# Altium schematic sheet CAM.SchDoc
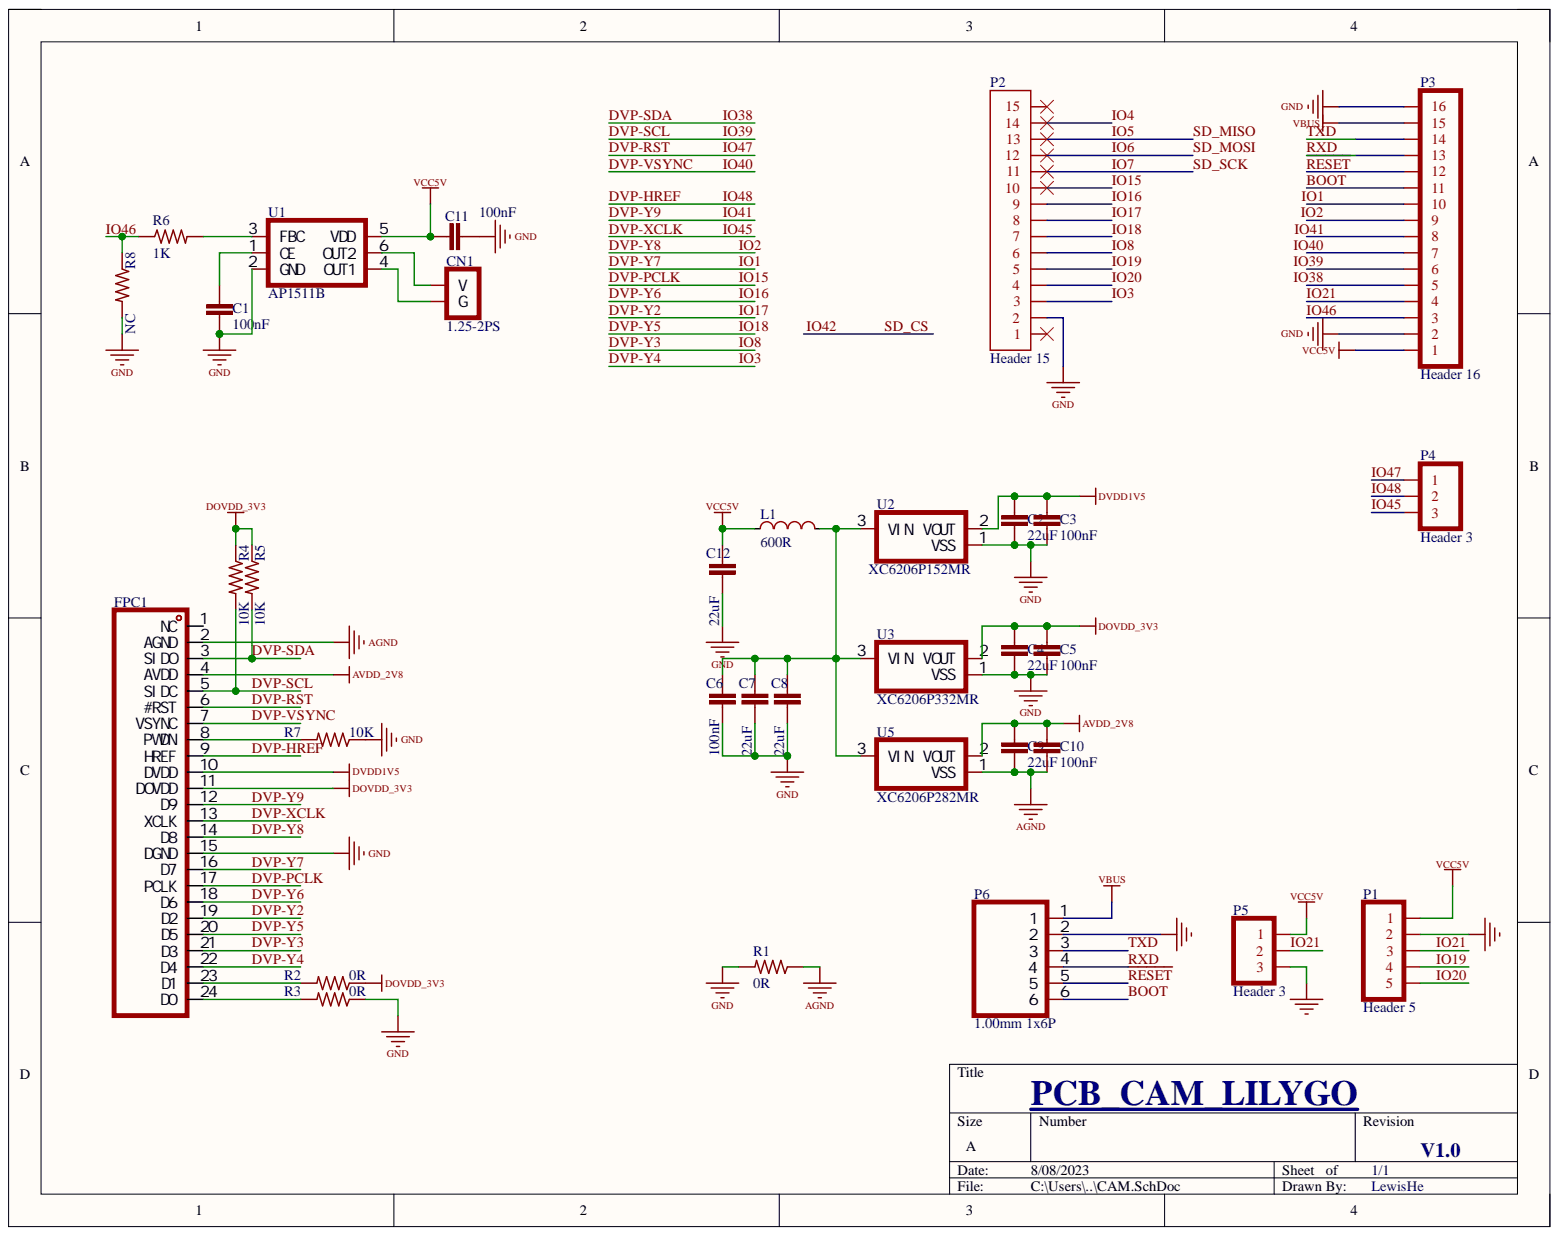
[diagram p0: CAM.SchDoc]

## Parts
C1  100nF  [C0402]
C2  22uF  [C0603]
C3  100nF  [C0603]
C4  22uF  [C0603]
C5  100nF  [C0603]
C6  100nF  [C0603]
C7  22uF  [C0603]
C8  22uF  [C0603]
C9  22uF  [C0603]
C10  100nF  [C0603]
C11  100nF  [C0603]
C12  22uF  [C0603]
CN1  1.25-2PS  [CONN-SMD_2P-P1.25_DEALON_1.25-2PWB]
FPC1  FPC-05FB-24PH20_1  [FPC-SMD_24P-P0.50_FPC-05FB-24PH20]  (value not drawn)
L1  600R  [L0805]
P1  Header 5  [HDR1X5]
P2  Header 15  [HDR1X15]
P3  Header 16  [HDR1X16]
P4  Header 3  [HDR1X3]
P5  Header 3  [HDR1X3-排母]
P6  1.00mm 1x6P  [CONN-SMD_HCTL_HC-1.0-6PWT]
R1  0R  [R0402]
R2  0R  [R0402]
R3  0R  [R0402]
R4  10K  [R0402]
R5  10K  [R0402]
R6  1K  [R0402]
R7  10K  [R0402]
R8  NC  [R0402]
U1  AP1511B  [SOT-26_L3.0-W1.6-P0.95-LS2.8-BL]
U2  XC6206P152MR  [SOT-23-3_L2.9-W1.6-P1.90-LS2.8-BR]
U3  XC6206P332MR  [SOT-23-3_L2.9-W1.6-P1.90-LS2.8-BR]
U5  XC6206P282MR  [SOT-23-3_L2.9-W1.6-P1.90-LS2.8-BR]

## Nets
AGND: C9.2 C10.2 FPC1.2(AGND) R1.1 U5.1
AVDD_2V8: C9.1 C10.1 FPC1.4(AVDD) U5.2
DOVDD_3V3: C4.1 C5.1 FPC1.11(DOVDD) R2.1 R4.1 R5.1 U3.2
DVDD1V5: C2.1 C3.1 FPC1.10(DVDD) U2.2
GND: C1.1 C2.2 C3.2 C4.2 C5.2 C6.2 C7.1 C8.1 C11.2 C12.1 CN1.3 CN1.4 FPC1.15(DGND) FPC1.25 FPC1.26 P1.2 P2.2 P3.2 P3.16 P5.3 P6.2 P6.7 P6.8 R1.2 R3.1 R7.1 R8.2 U1.2(GND) U2.1 U3.1
VBUS: P3.15 P6.1
VCC5V: C11.1 C12.2 L1.2 P1.1 P3.1 P5.1 U1.5(VDD)
BOOT: P3.11 P6.6
DVP-HREF: FPC1.9(HREF) P4.2
DVP-PCLK: FPC1.17(PCLK) P2.10
DVP-RST: FPC1.6(#RST) P4.1
DVP-SCL: FPC1.5(SIDC) P3.6 R4.2
DVP-SDA: FPC1.3(SIDO) P3.5 R5.2
DVP-VSYNC: FPC1.7(VSYNC) P3.7
DVP-XCLK: FPC1.13(XCLK) P4.3
DVP-Y2: FPC1.19(D2) P2.8
DVP-Y3: FPC1.21(D3) P2.6
DVP-Y4: FPC1.22(D4) P2.3
DVP-Y5: FPC1.20(D5) P2.7
DVP-Y6: FPC1.18(D6) P2.9
DVP-Y7: FPC1.16(D7) P3.10
DVP-Y8: FPC1.14(D8) P3.9
DVP-Y9: FPC1.12(D9) P3.8
IO4: P2.14
IO5: P2.13
IO6: P2.12
IO7: P2.11
IO19: P1.4 P2.5
IO20: P1.5 P2.4
IO21: P1.3 P3.4 P5.2
IO46: P3.3 R6.1 R8.1
~{RESET}: P3.12 P6.5
RXD: P3.13 P6.4
TXD: P3.14 P6.3
NetC1_2: C1.2 U1.1(CE)
NetC6_1: C6.1 C7.2 C8.2 L1.1 U2.3 U3.3 U5.3
NetCN1_1: CN1.1 U1.4(OUT1)
NetCN1_2: CN1.2 U1.6(OUT2)
NetFPC1_8: FPC1.8(PWDN) R7.2
NetFPC1_23: FPC1.23(D1) R2.2
NetFPC1_24: FPC1.24(D0) R3.2
NetR6_2: R6.2 U1.3(FBC)
IO42:

Not connected: FPC1 1(NC); P2 1 15

## Library data of the parts
C1-C12 = C0201: 0201  贴片电容; Component Kind: Standard; Library Name: 常用.SCHLIB
CN1 = 1.25-2PS: 1.25mm 1x2P 立贴 卧贴; 系统型号: 暂无; Component Kind: Standard; Library Name: 常用.SCHLIB; 立创链接: https://item.szlcsc.com/3172274.html
FPC1 = FPC-05FB-24PH20_1: OV2640 下接接口,https://item.szlcsc.com/3056929.html; Component Kind: Standard; Library Name: 常用.SCHLIB
L1 = L0603: Component Kind: Standard; Description: L0603; Library Name: 常用.SCHLIB
P1 = Header 5: 2.54mm 1x5 排针; Component Kind: Standard; Library Name: 常用.SCHLIB
P3 = Header 16: Header, 16-Pin; Component Kind: Standard; Library Name: 常用.SCHLIB
P5 = Header 3: 2.54mm 1x3 排针; Component Kind: Standard; Library Name: 常用.SCHLIB
R1-R8 = R0201: Component Kind: Standard; Library Name: 常用.SCHLIB
U2 = XC6206P122MR-G: 输出类型:固定;输出极性:正;最大输入电压:7V;输出电压:1.2V~1.2V;输出电流:60mA;电源纹波抑制比(PSRR):-;; Component Kind: Standard; ComponentLink1Description: 立创链接; ComponentLink1URL: https://item.szlcsc.com/418418.html; Library Name: 常用.SCHLIB
U3 = XC6206P182MR: 输出类型:固定;输出极性:正;最大输入电压:7V;输出电压:1.8V;输出电流:80mA;电源纹波抑制比(PSRR):-;; Component Kind: Standard; ComponentLink1Description: 立创链接; ComponentLink1URL: https://item.szlcsc.com/418419.html; Library Name: 常用.SCHLIB
U5 = XC6206-2.8V: 输出类型:固定;输出极性:正;最大输入电压:6V;输出电压:2.8V;输出电流:250mA;; Component Kind: Standard; ComponentLink1Description: 立创链接; ComponentLink1URL: https://item.szlcsc.com/3124288.html; Library Name: 常用.SCHLIB
P2 = Header 15: Component Kind: Standard; Description: Header 15; Library Name: 常用.SCHLIB
P4 = Header 3: Component Kind: Standard; Description: Header 3; Library Name: 常用.SCHLIB
P6 = 1.00mm 1x6P: Component Kind: Standard; ComponentLink1Description: 立创链接; ComponentLink1URL: https://item.szlcsc.com/3039046.html; ComponentLink2Description: 立创链接; ComponentLink2URL: https://item.szlcsc.com/3561357.html; Library Name: 常用.SCHLIB
U1 = AP1511B: Component Kind: Standard; ComponentLink1Description: 立创链接; ComponentLink1URL: https://item.szlcsc.com/324117.html; Library Name: 常用.SCHLIB
